# Altium schematic sheet p03_usbcdc.SchDoc
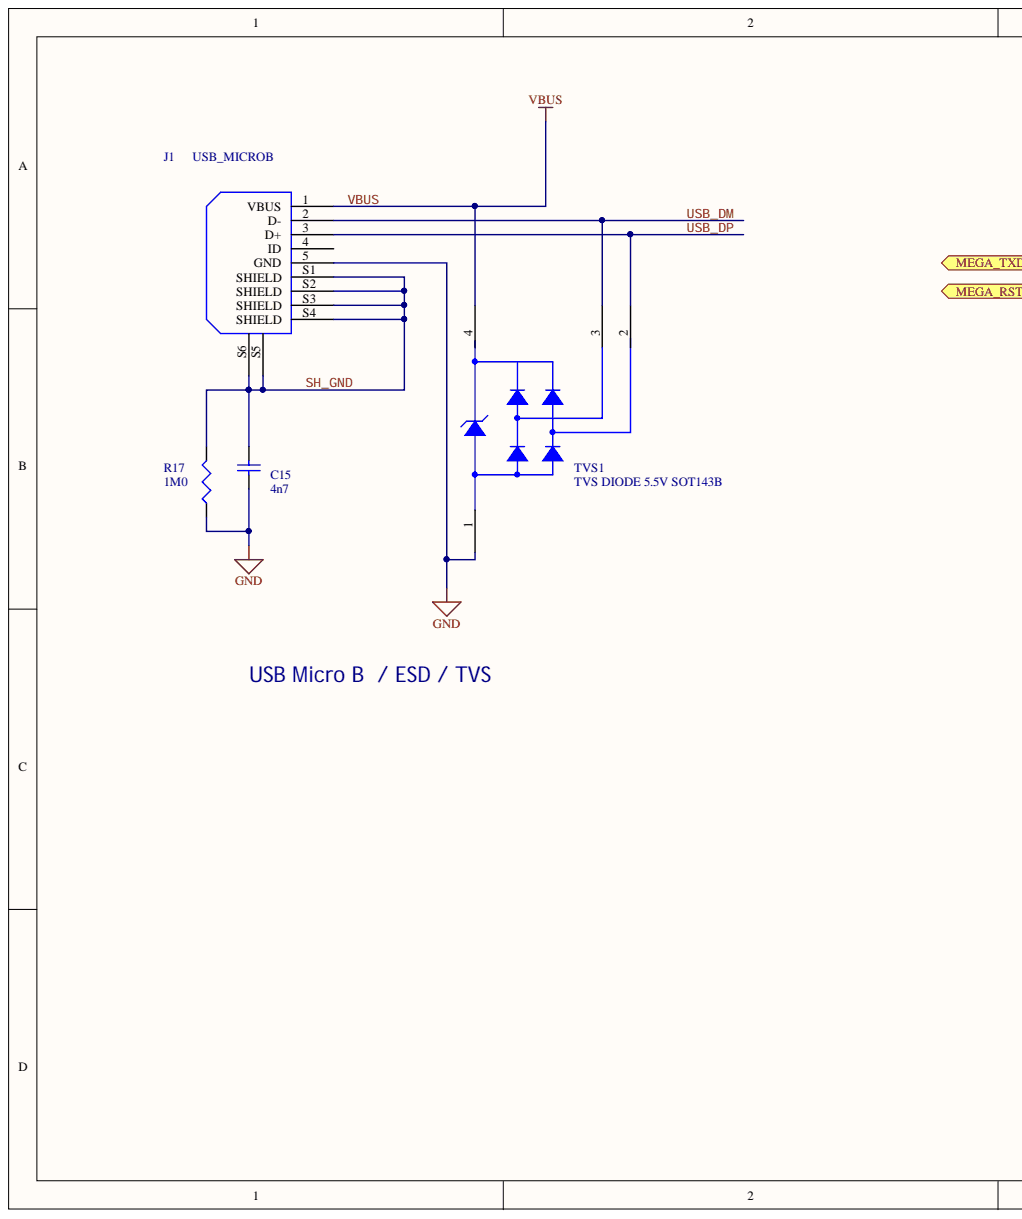
[diagram p0: p03_usbcdc.SchDoc, left]
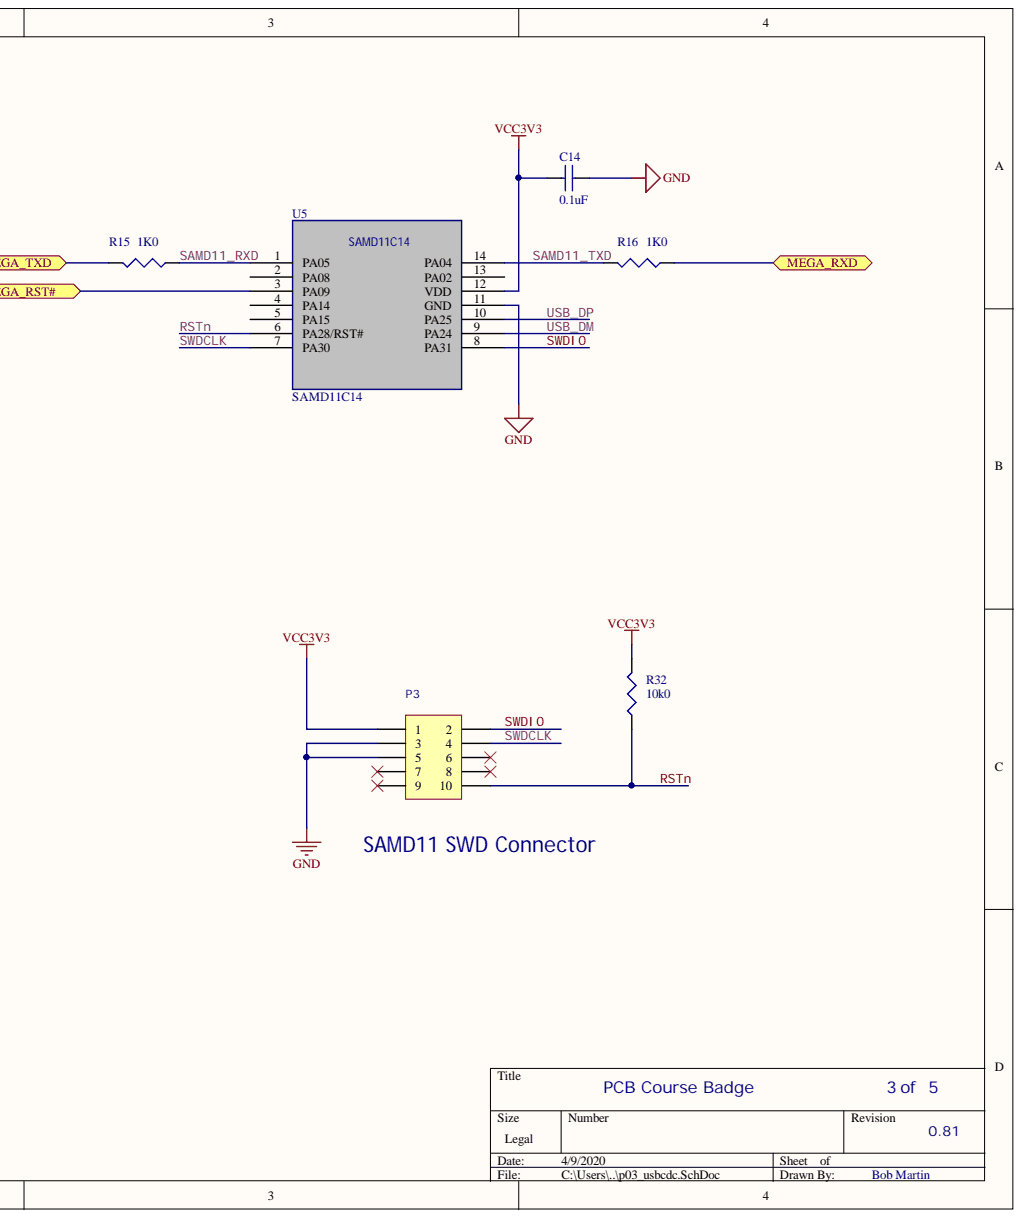
[diagram p1: p03_usbcdc.SchDoc, right]

## Parts
C14  value 0.1uF  [AP1-00039]
C15  value 4n7  [RAD-0.3]  (hidden comment: Cap)
J1  USB_MICROB  [AP8-01099]
P3  (no value)  [50mil Header]
R15  value 1K0  [J1-0603]  (hidden comment: Res3)
R16  value 1K0  [J1-0603]  (hidden comment: Res3)
R17  value 1M0  Detail: 1M0  [J1-0603]  (hidden comment: Res3)
R32  value 10k0  [J1-0603]  (hidden comment: Res3)
TVS1  value TVS DIODE 5.5V SOT143B  [tvs_SOT190P230X110-4N]  (hidden comment: PRTR5V0U2X)
U5  SAMD11C14  [*SOIC127P600X160-14N]

## Ports
MEGA_RST#, MEGA_RXD, MEGA_TXD

## Nets
GND: C14.1 C15.1 J1.5(GND) P3.3 P3.5 R17.1 TVS1.1 U5.11(GND)
VCC3V3: C14.2 P3.1 R32.2 U5.12(VDD)
MEGA_RST#: U5.3(PA09) ; port MEGA_RST#
MEGA_RXD: R16.1 ; port MEGA_RXD
MEGA_TXD: R15.2 ; port MEGA_TXD
RSTN: P3.10 R32.1 U5.6(PA28/RST#)
SAMD11_RXD: R15.1 U5.1(PA05)
SAMD11_TXD: R16.2 U5.14(PA04)
SH_GND: C15.2 J1.S1(SHIELD) J1.S2(SHIELD) J1.S3(SHIELD) J1.S4(SHIELD) J1.S5 J1.S6 R17.2
SWDCLK: P3.4 U5.7(PA30)
SWDIO: P3.2 U5.8(PA31)
USB_DM: J1.2(D-) TVS1.3 U5.9(PA24)
USB_DP: J1.3(D+) TVS1.2 U5.10(PA25)
VBUS: J1.1(VBUS) TVS1.4

Not connected: J1 4(ID); P3 6 7 8 9; U5 2(PA08) 4(PA14) 5(PA15) 13(PA02)

## Library data of the parts
C14 = A01-0461: Ceramic capacitor, SMD 0603, X5R, 10V, 10UF Â± 20%; Manufacturer: KEMET; MPN: C0603C104Z3VACTU; Database Table Name: AltiumComponents; Detail: CAP CER 0.1UF 25V Y5V 0603; Component Kind: Standard; Digi-Key PN: 399-1100-1-ND; Library Name: AltiumDbLib.DbLib
C15 = Cap: Capacitor; Manufacturer: KEMET; MPN: C0805C472KDRACAUTO; Detail: CAP CER 0805 4.7NF 1000V X7R 10%; Component Kind: Standard; Digi-Key PN: 399-16659-1-ND; Library Name: Miscellaneous Devices.IntLib; Signal Integrity: Cap; Simulation: CAP
J1 = USB_MICROB: USB micro AB, Surface mount signals and DIP shield; Manufacturer: Hirose Electric Co Ltd; MPN: ZX62D-B-5PA8(30); Detail: CONN RCPT USB2.0 MICRO B SMD R/A; Component Kind: Standard; Digi-Key PN: H125718CT-ND; Library Name: badge1.SCHLIB
P3 = Header 5X2: Header, 5-Pin, Dual row; Manufacturer: Amphenol ICC (FCI); MPN: 20021121-00010C4LF; Detail: CONN HEADER SMD 10POS 1.27MM; Value: CONN HEADER SMD 10POS 1.27MM; Component Kind: Standard; Digi-Key PN: 609-3695-1-ND; Library Name: Miscellaneous Connectors.IntLib
R15 R16 = Res3: Resistor; Manufacturer: Stackpole Electronics Inc; MPN: RNCS0603BKE1K00; Detail: RES 1K OHM 0.1% 1/16W 0603; Component Kind: Standard; Digi-Key PN: RNCS0603BKE1K00CT-ND; Library Name: Miscellaneous Devices.IntLib; Signal Integrity: Res; Simulation: RESISTOR
R17 = Res3: Resistor; Manufacturer: Stackpole Electronics Inc; MPN: RNCF0603BKC1M00; Component Kind: Standard; Digi-Key PN: RNCF0603BKC1M00CT-ND; Library Name: Miscellaneous Devices.IntLib; Signal Integrity: Res; Simulation: RESISTOR
R32 = Res3: Resistor; Manufacturer: Stackpole Electronics Inc; MPN: RNCP0603FTD10K0; Detail: RES 10K OHM 1% 1/8W 0603; Component Kind: Standard; Digi-Key PN: RNCP0603FTD10K0CT-ND; Library Name: Miscellaneous Devices.IntLib; Signal Integrity: Res; Simulation: RESISTOR
TVS1 = PRTR5V0U2X: Double rail-to-rail USB ESD protection diode; Manufacturer: Nexperia USA Inc.; Component Kind: Standard; Detail: TVS DIODE 5.5V SOT143B; Digi-Key PN: 1727-3884-1-ND; Library Name: badge1.SCHLIB; MPN: PRTR5V0U2X
U5 = SAMD11C14: Manufacturer: Microchip Technology; MPN: ATSAMD11C14A-SSNT; Detail: ARM® Cortex®-M0+ SAM D11C Microcontroller IC 32-Bit 48MHz 16KB (16K x 8) FLASH 14-SOIC; Component Kind: Standard; Digi-Key PN: ATSAMD11C14A-SSNTCT-ND; Library Name: badge1.SCHLIB; Value: SAMD11C14
